# Altium schematic sheet FTDI.SchDoc
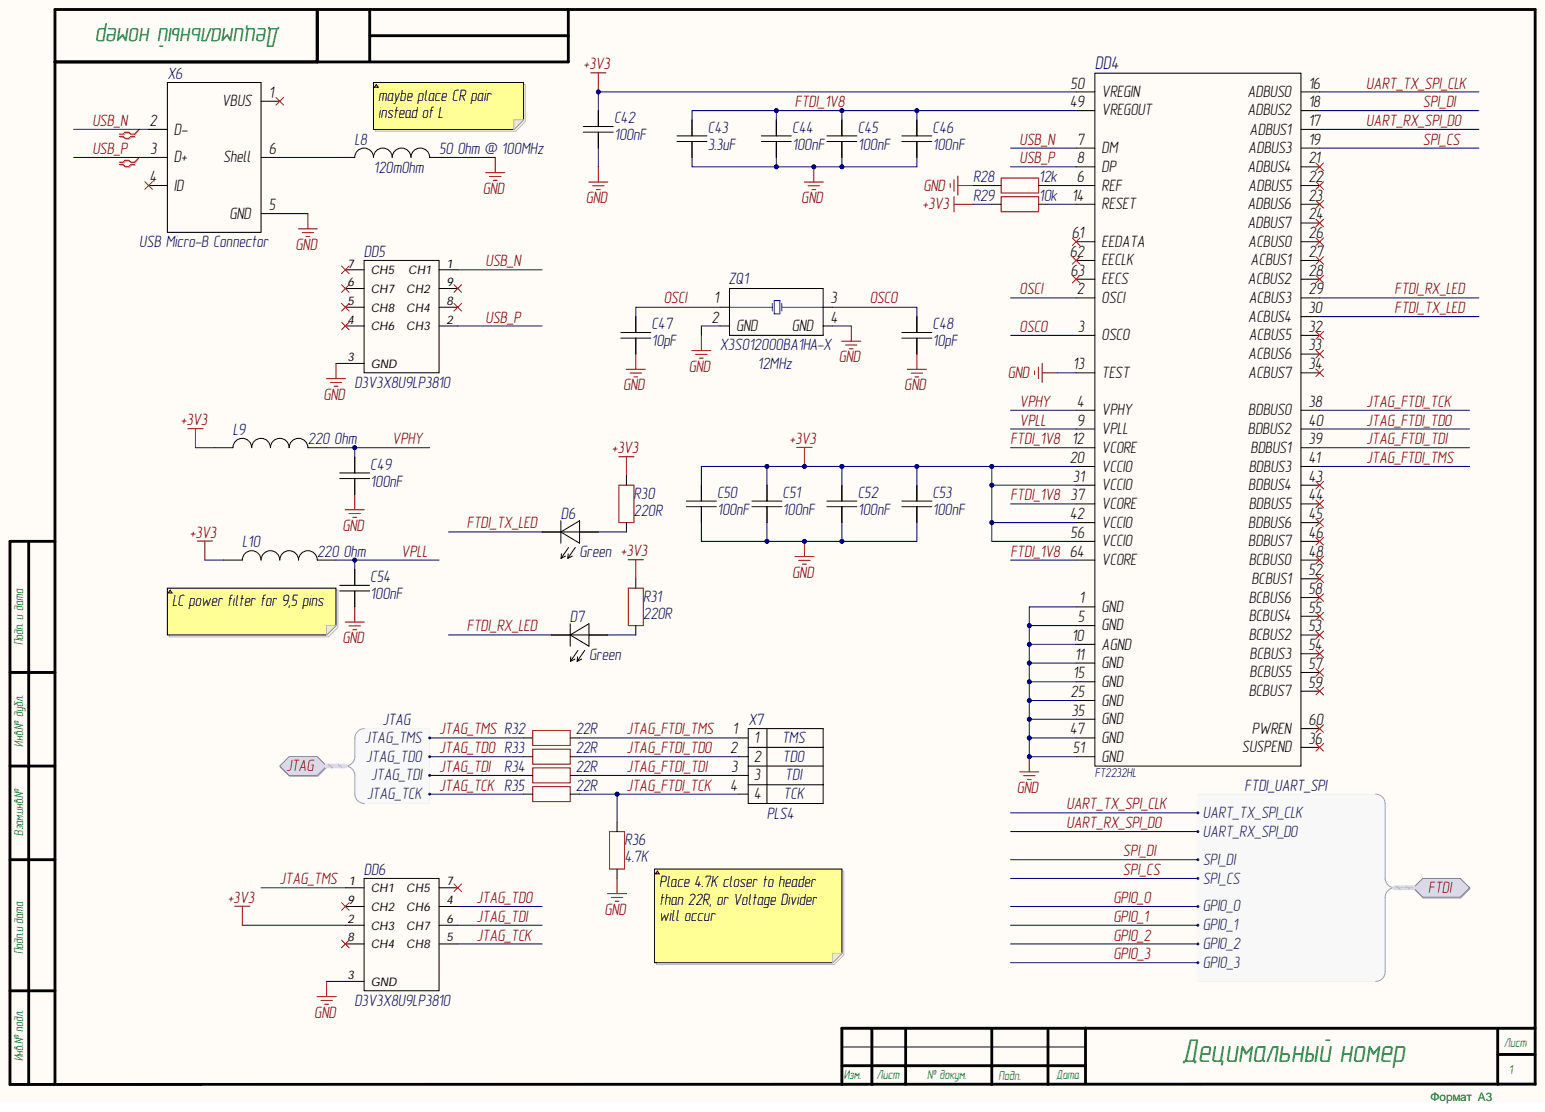
[diagram p0: FTDI.SchDoc]

## Parts
C42  value 100nF  [CAP0402]  (hidden comment: 100nF 50V)
C43  value 3.3uF  [CAP0402]  (hidden comment: 3.3uF 6.3V)
C44  value 100nF  [CAP0402]  (hidden comment: 100nF 50V)
C45  value 100nF  [CAP0402]  (hidden comment: 100nF 50V)
C46  value 100nF  [CAP0402]  (hidden comment: 100nF 50V)
C47  value 10pF  [CAP0402]  (hidden comment: 10pF 50V)
C48  value 10pF  [CAP0402]  (hidden comment: 10pF 50V)
C49  value 100nF  [CAP0402]  (hidden comment: 100nF 50V)
C50  value 100nF  [CAP0402]  (hidden comment: 100nF 50V)
C51  value 100nF  [CAP0402]  (hidden comment: 100nF 50V)
C52  value 100nF  [CAP0402]  (hidden comment: 100nF 50V)
C53  value 100nF  [CAP0402]  (hidden comment: 100nF 50V)
C54  value 100nF  [CAP0402]  (hidden comment: 100nF 50V)
D6  Green  [LED603]
D7  Green  [LED603]
DD4  =Value  [LQFP64]
DD5  TVS at USB diff pair  [U-DFN3810-9]  (value not drawn)
DD6  TVS Diode at JTAG  [U-DFN3810-9]  (value not drawn)
L8  50 Ohm @ 100MHz  DCResistance: 120mOhm  [CAPC0603N]
L9  220 Ohm  [CAPC0603N]
L10  220 Ohm  [CAPC0603N]
R28  12k  [RESC0402(1005)_M]
R29  10k  [RESC0402(1005)_M]
R30  220R  [RESC0402(1005)_M]
R31  220R  [RESC0402(1005)_M]
R32  value 22R  [RESC0402(1005)_M]  (hidden comment: 22R 1/16W)
R33  value 22R  [RESC0402(1005)_M]  (hidden comment: 22R 1/16W)
R34  value 22R  [RESC0402(1005)_M]  (hidden comment: 22R 1/16W)
R35  value 22R  [RESC0402(1005)_M]  (hidden comment: 22R 1/16W)
R36  value 4.7K  [RESC0402(1005)_M]  (hidden comment: 4.7K 1/16W)
X6  USB Micro-B Connector  ClassName: Connector  [AMPHENOL_10118194-0001LF]
X7  PLS4  Wire1: TMS  Wire2: TDO  Wire3: TDI  Wire4: TCK  [PLS4]
ZQ1  12MHz  [TSX-3225_V]

## Ports
FTDI, FTDI.GPIO_0, FTDI.GPIO_1, FTDI.GPIO_2, FTDI.GPIO_3, FTDI.SPI_CS, FTDI.SPI_DI, FTDI.UART_RX_SPI_DO, FTDI.UART_TX_SPI_CLK, JTAG, JTAG.JTAG_TCK, JTAG.JTAG_TDI, JTAG.JTAG_TDO, JTAG.JTAG_TMS

## Nets
+3V3: C42.1 C50.2 C51.2 C52.2 C53.2 DD4.20(VCCIO) DD4.31(VCCIO) DD4.42(VCCIO) DD4.50(VREGIN) DD4.56(VCCIO) DD6.2(CH3) L9.1 L10.1 R29.2 R30.2 R31.2
GND: C42.2 C43.1 C44.2 C45.2 C46.2 C47.1 C48.1 C49.1 C50.1 C51.1 C52.1 C53.1 C54.1 DD4.1(GND) DD4.5(GND) DD4.10(AGND) DD4.11(GND) DD4.13(TEST) DD4.15(GND) DD4.25(GND) DD4.35(GND) DD4.47(GND) DD4.51(GND) DD5.3(GND) DD6.3(GND) L8.2 R28.2 R36.2 X6.5(GND) ZQ1.2(GND) ZQ1.4(GND)
FTDI_1V8: C43.2 C44.1 C45.1 C46.1 DD4.12(VCORE) DD4.37(VCORE) DD4.49(VREGOUT) DD4.64(VCORE)
FTDI_RX_LED: D7.1 DD4.29(ACBUS3)
FTDI_TX_LED: D6.1 DD4.30(ACBUS4)
JTAG_FTDI_TCK: DD4.38(BDBUS0) R35.2 R36.1 X7.4
JTAG_FTDI_TDI: DD4.39(BDBUS1) R34.2 X7.3
JTAG_FTDI_TDO: DD4.40(BDBUS2) R33.2 X7.2
JTAG_FTDI_TMS: DD4.41(BDBUS3) R32.2 X7.1
JTAG_TCK: DD6.5(CH8) R35.1 ; port JTAG
JTAG_TDI: DD6.6(CH7) R34.1 ; port JTAG
JTAG_TDO: DD6.4(CH6) R33.1 ; port JTAG
JTAG_TMS: DD6.1(CH1) R32.1 ; port JTAG
OSCI: C47.2 DD4.2(OSCI) ZQ1.1
OSCO: C48.2 DD4.3(OSCO) ZQ1.3
SPI_CS: DD4.19(ADBUS3) ; port FTDI
SPI_DI: DD4.18(ADBUS2) ; port FTDI
UART_RX_SPI_DO: DD4.17(ADBUS1) ; port FTDI
UART_TX_SPI_CLK: DD4.16(ADBUS0) ; port FTDI
USB_N: DD4.7(DM) DD5.1(CH1) X6.2(D-)
USB_P: DD4.8(DP) DD5.2(CH3) X6.3(D+)
VPHY: C49.2 DD4.4(VPHY) L9.2
VPLL: C54.2 DD4.9(VPLL) L10.2
NetD6_2: D6.2 R30.1
NetD7_2: D7.2 R31.1
NetDD4_6: DD4.6(REF) R28.1
NetDD4_14: DD4.14(RESET) R29.1
NetL8_1: L8.1 X6.6(Shell)
GPIO_0: ; port FTDI
GPIO_1: ; port FTDI
GPIO_2: ; port FTDI
GPIO_3: ; port FTDI

Not connected: DD4 21(ADBUS4) 22(ADBUS5) 23(ADBUS6) 24(ADBUS7) 26(ACBUS0) 27(ACBUS1) 28(ACBUS2) 32(ACBUS5) 33(ACBUS6) 34(ACBUS7) 36(SUSPEND) 43(BDBUS4) 44(BDBUS5) 45(BDBUS6) 46(BDBUS7) 48(BCBUS0) 52(BCBUS1) 53(BCBUS2) 54(BCBUS3) 55(BCBUS4) 57(BCBUS5) 58(BCBUS6) 59(BCBUS7) 60(PWREN) 61(EEDATA) 62(EECLK) 63(EECS); DD5 4(CH6) 5(CH8) 6(CH7) 7(CH5) 8(CH4) 9(CH2); DD6 7(CH5) 8(CH4) 9(CH2); X6 1(VBUS) 4(ID)

## Library data of the parts
C42 C44-C46 C49-C54 = CAP0402: Manufacturer: Samsung Electro-Mechanics; ManufacturerAlt: JLCPCB; MPN: CL05B104KB54PNC; MPNAlt: C307331; BOMDescription: SMD CHIP CERAMIC CAPACITOR CAP0402 100nF 10% 50V  CL05B104KB54PNC; Database Table Name: Symbol_SchLib; MntType: SMD; Notes: Basic Part; Tolerance: 10%; CFootprint: CAP0402; ClassName: Capacitor; Component Kind: Standard; ComponentLink1Description: Datasheet; ComponentLink1URL: https://datasheet.lcsc.com/lcsc/1810191222_Samsung-Electro-Mechanics-CL05B104KB54PNC_C307331.pdf; Height: 0.5mm; Library Name: Library.DbLib; MSL: MSL 1; Package: CAP0402; Part Number: CAP0402; PartType: CHIP CERAMIC CAPACITOR; Pins: 2; RoHS: Comply; Voltage: 50V; Weight: 1.500mg
C43 = CAP0402: Manufacturer: TDK; ManufacturerAlt: JLCPCB; MPN: C1005X5R0J335MTJ00E; MPNAlt: C694161; BOMDescription: SMD CHIP CERAMIC CAPACITOR CAP0402 3.3uF  6.3V  C1005X5R0J335MTJ00E; Database Table Name: Symbol_SchLib; MntType: SMD; CFootprint: CAP0402; ClassName: Capacitor; Component Kind: Standard; ComponentLink1Description: Datasheet; Library Name: Library.DbLib; MSL: MSL 1; Package: CAP0402; Part Number: CAP0402; PartType: CHIP CERAMIC CAPACITOR; Pins: 2; RoHS: Comply; Voltage: 6.3V
C47 C48 = CAP0402: Manufacturer: Samsung Electro-Mechanics; ManufacturerAlt: JLCPCB; MPN: CL05C100JB5NNNC; MPNAlt: C32949; BOMDescription: SMD CHIP CERAMIC CAPACITOR CAP0402 10pF  50V  CL05C100JB5NNNC; Database Table Name: Symbol_SchLib; MntType: SMD; CFootprint: CAP0402; ClassName: Capacitor; Component Kind: Standard; ComponentLink1Description: Datasheet; Library Name: Library.DbLib; MSL: MSL 1; Package: CAP0402; Part Number: CAP0402; PartType: CHIP CERAMIC CAPACITOR; Pins: 2; RoHS: Comply; Voltage: 50V
D6 D7 = LEDC: Manufacturer: Everlight Elec; ManufacturerAlt: JLCPCB; MPN: 19-217/GHC-YR1S2/3T; MPNAlt: C72043; BOMDescription: SMD CHIP LED LED603 Green    19-217/GHC-YR1S2/3T; Database Table Name: Symbol_SchLib; MntType: SMD; CFootprint: LED603; ClassName: LED; Component Kind: Standard; ComponentLink1Description: Datasheet; Library Name: Library.DbLib; MSL: MSL 5; Package: LED603; Part Number: LEDC; PartType: CHIP LED; Pins: 2; RoHS: Comply; Value: Green
DD4 = FT2232HL: Manufacturer: FTDI; Database Table Name: Symbol_SchLib; MntType: SMD; BOMDescription: FT2232HL; CFootprint: LQFP64; ClassName: FTDI; Component Kind: Standard; ComponentLink1Description: Datasheet; ComponentLink1URL: https://ftdichip.com/wp-content/uploads/2020/07/DS_FT2232H.pdf; Library Name: Library.DbLib; MPN: FT2232HL; MSL: MSL 1; Package: LQFP64; Part Number: FT2232HL; PartType: FTDI; Pins: 64; RoHS: Comply
DD5 = D3V3X8U9LP3810: Manufacturer: DiodesInc; ManufacturerAlt: JLCPCB; MPNAlt: C1973794; Database Table Name: Symbol_SchLib; MntType: SMD; BOMDescription: D3V3X8U9LP3810; CFootprint: U-DFN3810-9; ClassName: TVS; Component Kind: Standard; ComponentLink1Description: Datasheet; ComponentLink1URL: https://www.elitan.ru/pdf/1/1/5/3/0/11530248.pdf; Library Name: Library.DbLib; MPN: D3V3X8U9LP3810; MSL: MSL 1; Package: U-DFN3810-9; Part Number: D3V3X8U9LP3810; PartType: TVS; Pins: 9; RoHS: Comply
DD6 = D3V3X8U9LP3810: Manufacturer: DiodesInc; Database Table Name: Symbol_SchLib; MntType: SMD; BOMDescription: D3V3X8U9LP3810; CFootprint: U-DFN3810-9; ClassName: TVS; Component Kind: Standard; ComponentLink1Description: Datasheet; ComponentLink1URL: https://www.diodes.com/assets/Datasheets/D3V3X8U9LP3810.pdf; Library Name: Library.DbLib; MPN: D3V3X8U9LP3810; MSL: MSL 1; Package: U-DFN3810-9; Part Number: D3V3X8U9LP3810; PartType: TVS; Pins: 9; RoHS: Comply
L8 = Inductor_SMD: Manufacturer: FH(Guangdong Fenghua Advanced Tech); ManufacturerAlt: JLCPCB; MPN: CBW160808U500T; MPNAlt: C394476; BOMDescription: SMD INDUCTOR CAPC0603N 50 Ohm @ 100MHz    CBW160808U500T; Database Table Name: Symbol_SchLib; MntType: SMD; CFootprint: CAPC0603N; ClassName: Inductor; Component Kind: Standard; ComponentLink1Description: Datasheet; ComponentLink1URL: https://datasheet.lcsc.com/lcsc/1912111437_FH-Guangdong-Fenghua-Advanced-Tech-CBW160808U500T_C394476.pdf; Library Name: Library.DbLib; MSL: MSL 1; Package: CAPC0603N; Part Number: Inductor_SMD; PartType: INDUCTOR; Pins: 2; RoHS: Comply; Value: 50 Ohm @ 100MHz
L9 L10 = BLM18KG221SN1D: Manufacturer: Murata; ManufacturerAlt: JLCPCB; MPNAlt: C88980; BOMDescription: SMD Ferrite Beads CAPC0603N 220 Ohm 25%   BLM18KG221SN1D; Database Table Name: Symbol_SchLib; MntType: SMD; Tolerance: 25%; CFootprint: CAPC0603N; ClassName: Ferrite Beads; Component Kind: Standard; ComponentLink1Description: Datasheet; ComponentLink1URL: https://ru.mouser.com/datasheet/2/281/ENFA0003-1915778.pdf; Height: 0.6mm; Library Name: Library.DbLib; MPN: BLM18KG221SN1D; MSL: MSL 1; Package: CAPC0603N; Part Number: BLM18KG221SN1D; PartType: Ferrite Beads; Pins: 2; RoHS: Comply; Value: 220 Ohm; Weight: 35mg
R28 = RES0402: Manufacturer: UNI-ROYAL(Uniroyal Elec); ManufacturerAlt: JLCPCB; MPN: 0402WGF1202TCE; MPNAlt: C25752; BOMDescription: SMD CHIP RESISTOR RESC0402(1005)_M 12k    0402WGF1202TCE; Database Table Name: Symbol_SchLib; MntType: SMD; CFootprint: RESC0402(1005)_M; ClassName: Resistor; Component Kind: Standard; ComponentLink1Description: Datasheet; Library Name: Library.DbLib; MSL: MSL 1; Package: RESC0402(1005)_M; Part Number: RES0402; PartType: CHIP RESISTOR; Pins: 2; RoHS: Comply; Value: 12k
R29 = RES0402: Manufacturer: UNI-ROYAL(Uniroyal Elec); ManufacturerAlt: JLCPCB; MPN: 0402WGF1002TCE; MPNAlt: C25744; BOMDescription: SMD CHIP RESISTOR RESC0402(1005)_M 10k    0402WGF1002TCE; Database Table Name: Symbol_SchLib; MntType: SMD; CFootprint: RESC0402(1005)_M; ClassName: Resistor; Component Kind: Standard; ComponentLink1Description: Datasheet; Library Name: Library.DbLib; MSL: MSL 1; Package: RESC0402(1005)_M; Part Number: RES0402; PartType: CHIP RESISTOR; Pins: 2; RoHS: Comply; Value: 10k
R30 R31 = RES0402: Manufacturer: UNI-ROYAL(Uniroyal Elec); ManufacturerAlt: JLCPCB; MPN: 0402WGF2200TCE; MPNAlt: C25091; BOMDescription: SMD CHIP RESISTOR RESC0402(1005)_M 220R    0402WGF2200TCE; Database Table Name: Symbol_SchLib; MntType: SMD; CFootprint: RESC0402(1005)_M; ClassName: Resistor; Component Kind: Standard; ComponentLink1Description: Datasheet; Library Name: Library.DbLib; MSL: MSL 1; Package: RESC0402(1005)_M; Part Number: RES0402; PartType: CHIP RESISTOR; Pins: 2; RoHS: Comply; Value: 220R
R32-R35 = RES0402: Manufacturer: UNI-ROYAL; ManufacturerAlt: JLCPCB; MPN: 0402WGF220JTCE; MPNAlt: C25092; BOMDescription: SMD CHIP RESISTOR RESC0402(1005)_M 22R 1% 50V 200ppm/C 0402WGF220JTCE; Database Table Name: Symbol_SchLib; MntType: SMD; Notes: Basic Part; TempCoeff: 200ppm/C; Tolerance: 1%; Voltage: 50V; CFootprint: RESC0402(1005)_M; ClassName: Resistor; Component Kind: Standard; ComponentLink1Description: Datasheet; ComponentLink1URL: https://datasheet.lcsc.com/lcsc/1811011231_UNI-ROYAL-Uniroyal-Elec-0402WGF220JTCE_C25092.pdf; Height: 0.35mm; Library Name: Library.DbLib; MSL: MSL 1; Package: RESC0402(1005)_M; Part Number: RES0402; PartType: CHIP RESISTOR; Pins: 2; Power: 1/16W; RoHS: Comply
R36 = RES0402: Manufacturer: UNI-ROYAL; ManufacturerAlt: JLCPCB; MPN: 0402WGF4701TCE; MPNAlt: C25900; BOMDescription: SMD CHIP RESISTOR RESC0402(1005)_M 4.7K 1% 50V 100ppm/C 0402WGF4701TCE; Database Table Name: Symbol_SchLib; MntType: SMD; Notes: Basic Part; TempCoeff: 100ppm/C; Tolerance: 1%; Voltage: 50V; CFootprint: RESC0402(1005)_M; ClassName: Resistor; Component Kind: Standard; ComponentLink1Description: Datasheet; ComponentLink1URL: https://datasheet.lcsc.com/lcsc/1810301132_UNI-ROYAL-Uniroyal-Elec-0402WGF4701TCE_C25900.pdf; Height: 0.35mm; Library Name: Library.DbLib; MSL: MSL 1; Package: RESC0402(1005)_M; Part Number: RES0402; PartType: CHIP RESISTOR; Pins: 2; Power: 1/16W; RoHS: Comply
X6 = 10118194-0001LF: USB 2.0 Micro-B Female Connector; Manufacturer: Amphenol; ManufacturerAlt: JLCPCB; MPNAlt: C132563; BOMDescription: SMD/TH CONNECTOR AMPHENOL_10118194-0001LF USB Micro-B Connector    10118194-0001LF; Database Table Name: Symbol_SchLib; MntType: SMD/TH; CFootprint: AMPHENOL_10118194-0001LF; Component Kind: Standard; ComponentLink1Description: Datasheet; ComponentLink1URL: https://cdn.amphenol-icc.com/media/wysiwyg/files/drawing/10118194.pdf; Library Name: Library.DbLib; MPN: 10118194-0001LF; MSL: MSL 1; Package: AMPHENOL_10118194-0001LF; Part Number: 10118194-0001LF; PartType: CONNECTOR; Pins: 6; RoHS: Comply; System: Connector; Value: USB Micro-B Connector
X7 = PLS4: JTAG Header; BOMDescription: DIP CONNECTOR CONNPLS4     PLS4; Database Table Name: Symbol_SchLib; MntType: DIP; System: Connector; CFootprint: PLS4; ClassName: Connector; Component Kind: Standard; ComponentLink1Description: Datasheet; Library Name: Library.DbLib; MPN: PLS4; MSL: MSL 1; Package: CONNPLS4; Part Number: PLS4; PartType: CONNECTOR; Pins: 4; RoHS: Comply
ZQ1 = X3S012000BA1HA-X: Manufacturer: HELE(HARMONY ELEC); Database Table Name: Symbol_SchLib; MntType: SMD; BOMDescription: X3S012000BA1HA-X; CFootprint: TSX-3225_V; ClassName: CRYSTAL; Component Kind: Standard; ComponentLink1Description: Datasheet; ComponentLink1URL: https://datasheet.lcsc.com/lcsc/1811121833_HELE-Harmony-Elec-X3S012000BA1HA-X_C254364.pdf; Library Name: Library.DbLib; MPN: X3S012000BA1HA-X; MSL: MSL 1; Package: SMD3225; Part Number: X3S012000BA1HA-X; PartType: CRYSTAL; Pins: 4; RoHS: Comply; Value: 12MHz
